# Altium schematic sheet karmanDB_sensors1.SchDoc
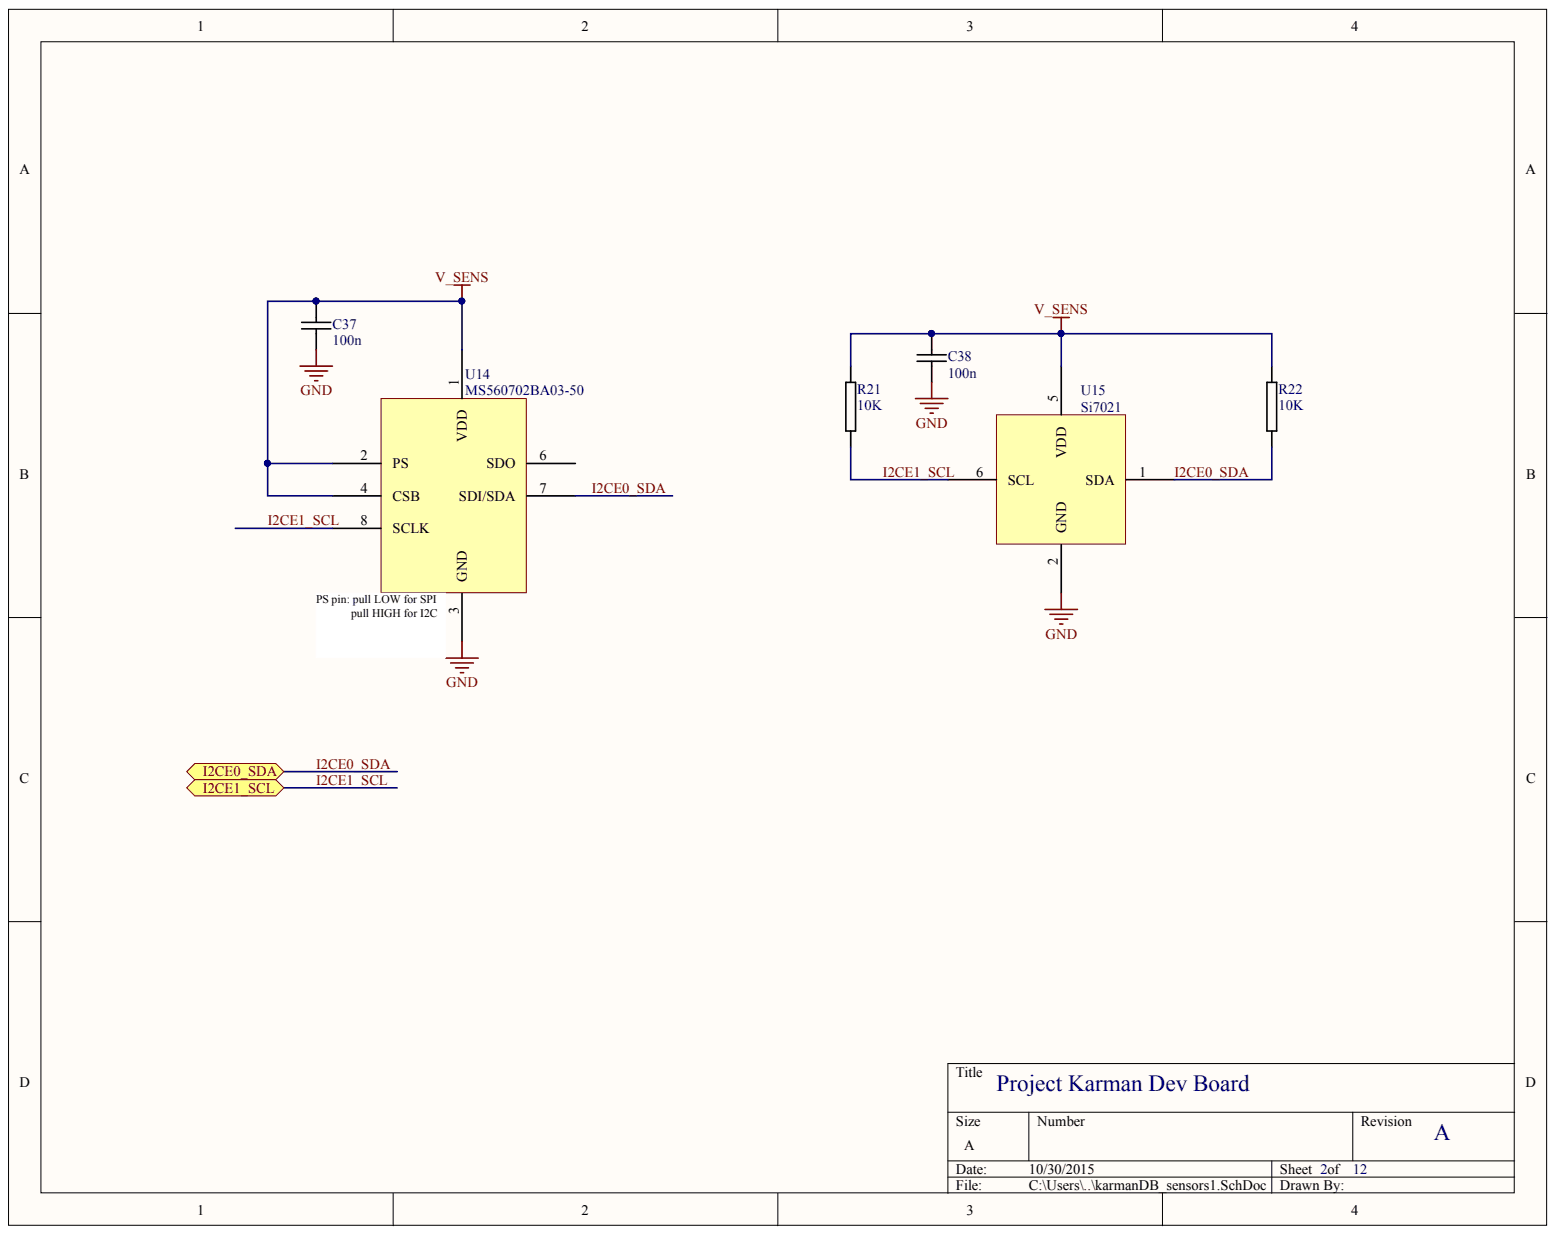
[diagram p0: karmanDB_sensors1.SchDoc]

## Parts
C37  100n  [MURATA_GRM_0603]
C38  100n  [MURATA_GRM_0603]
R21  10K  [PANASONIC_ERJ3R]
R22  10K  [PANASONIC_ERJ3R]
U14  MS560702BA03-50
U15  Si7021

## Ports
I2CE0_SDA, I2CE1_SCL

## Nets
GND: C37.2 C38.2 U14.3(GND) U15.2(GND)
V_SENS: C37.1 C38.1 R21.1 R22.1 U14.1(VDD) U14.2(PS) U14.4(CSB) U15.5(VDD)
I2CE0_SDA: R22.2 U14.7(SDI/SDA) U15.1(SDA) ; port I2CE0_SDA
I2CE1_SCL: R21.2 U14.8(SCLK) U15.6(SCL) ; port I2CE1_SCL

Not connected: U14 6(SDO)

## Library data of the parts
C37 C38 = CAP_MURATA_GRM188R71C104KA01D: CAP CER 0.1UF 16V 10% X7R 0603; Manufacturer: Murata Electronics North America; Capacitance: 0.1µF; Applications: General Purpose; Category: Capacitors; Component Kind: Standard; ComponentLink1Description: http://www.murata.com/~/media/webrenewal/support/library/catalog/products/capacitor/mlcc/c02e.ashx; ComponentLink1URL: http://www.murata.com/~/media/webrenewal/support/library/catalog/products/capacitor/mlcc/c02e.ashx; Features: -; Height - Seated (Max): -; Lead Spacing: -; Lead Style: -; Library Name: project_symbols.SchLib; Manufacturer Part Number: GRM188R71C104KA01D; Mounting Type: Surface Mount, MLCC; Operating Temperature: -55°C ~ 125°C; Package / Case: 0603 (1608 Metric); Packaging: Cut Tape (CT); Pricing: 1=0.1, 10=0.019, 50=0.0102, 100=0.0086, 250=0.00704, 500=0.006, 1000=0.00468 (USD); Ratings: -; RoHS: RoHS Compliant; Size / Dimension: 0.063" L x 0.031" W (1.60mm x 0.80mm); Stock 1: 2486193; Supplier 1: Digi-Key; Supplier Part Number 1: 490-1532-1-ND; Temperature Coefficient: X7R; Thickness (Max): 0.035" (0.90mm); Tolerance: ±10%; Voltage - Rated: 16V
R21 R22 = RES_PANASONIC_ERJ-3GEYJ103V: RES SMD 10K OHM 5% 1/10W 0603; Manufacturer: Panasonic Electronic Components; Power (Watts): 0.1W, 1/10W; Temperature Coefficient: ±200ppm/°C; Category: Resistors; Component Kind: Standard; ComponentLink1Description: http://industrial.panasonic.com/www-cgi/jvcr13pz.cgi?E+PZ+3+AOA0001+ERJ3GEYJ103V+7+WW; ComponentLink1URL: http://industrial.panasonic.com/www-cgi/jvcr13pz.cgi?E+PZ+3+AOA0001+ERJ3GEYJ103V+7+WW; Composition: Thick Film; Features: Automotive AEC-Q200; Height: 0.022" (0.55mm); Library Name: project_symbols.SchLib; Manufacturer Part Number: ERJ-3GEYJ103V; Number of Terminations: 2; Operating Temperature: -55°C ~ 155°C; Package / Case: 0603 (1608 Metric); Packaging: Cut Tape (CT); Pricing: 1=0.1, 50=0.0096, 100=0.0071, 250=0.00544, 500=0.00436, 1000=0.00321 (USD); Resistance (Ohms): 10k; RoHS: RoHS Compliant; Size / Dimension: 0.063" L x 0.031" W (1.60mm x 0.80mm); Stock 1: 4229597; Supplier 1: Digi-Key; Supplier Device Package: 0603; Supplier Part Number 1: P10KGCT-ND; Tolerance: ±5%
U14 = MS560702BA03-50: BAROMETRIC PRESSURE SENSOR; Manufacturer: TE Connectivity Measurement Specialties; Accuracy: ±0.022 PSI (±0.15 kPa); Maximum Pressure: 87.02 PSI (600 kPa); Operating Pressure: 0.15 PSI ~ 17.4 PSI (1 kPa ~ 120 kPa); Output: 24 b; Output Type: I²C, SPI; Port Style: No Port; Pressure Type: Absolute; Voltage - Supply: 1.8 V ~ 3.6 V; Category: Sensors, Transducers; Component Kind: Standard; ComponentLink1Description: http://www.meas-spec.com/downloads/MS5607-02BA03.pdf; ComponentLink1URL: http://www.meas-spec.com/downloads/MS5607-02BA03.pdf; Features: -; Manufacturer Part Number: MS560702BA03-50; Operating Temperature: -40°C ~ 85°C; Port Size: -; Pricing 1: 1=5.98, 10=4.664, 25=3.784, 50=3.344, 100=3.256, 250=2.992, 500=2.728, 750=2.64, 1000=2.552 (USD); RoHS: RoHS Compliant; Stock 1: 9112; Supplier 1: Digi-Key; Supplier Part Number 1: 223-1198-1-ND; Library Name: karman_pcb.SCHLIB
U15 = SI7021-A20-GM: IC TEMP SENSOR RH 3% 6DFN; Manufacturer: Silicon Labs; Accuracy: ±3% RH; Humidity Range: 0 ~ 100% RH; Output: 12b; Output Type: I²C; Response Time: 18S; Sensor Type: Humidity, Temperature; Voltage - Supply: 1.9 V ~ 3.6 V; Category: Sensors, Transducers; Component Kind: Standard; ComponentLink1Description: https://www.silabs.com/Support%20Documents/TechnicalDocs/Si7021-A20.pdf; ComponentLink1URL: https://www.silabs.com/Support%20Documents/TechnicalDocs/Si7021-A20.pdf; Manufacturer Part Number: SI7021-A20-GM; Mounting Type: Surface Mount; Operating Temperature: -40°C ~ 85°C; Pricing 1: 1=3.87, 25=3.5496, 100=3.227, 1000=2.90426 (USD); RoHS: RoHS Compliant; Sensitivity: -; Stock 1: 755; Supplier 1: Digi-Key; Supplier Part Number 1: 336-3140-5-ND; Library Name: karman_pcb.SCHLIB
